# Altium schematic sheet BEMF_Detector.SchDoc
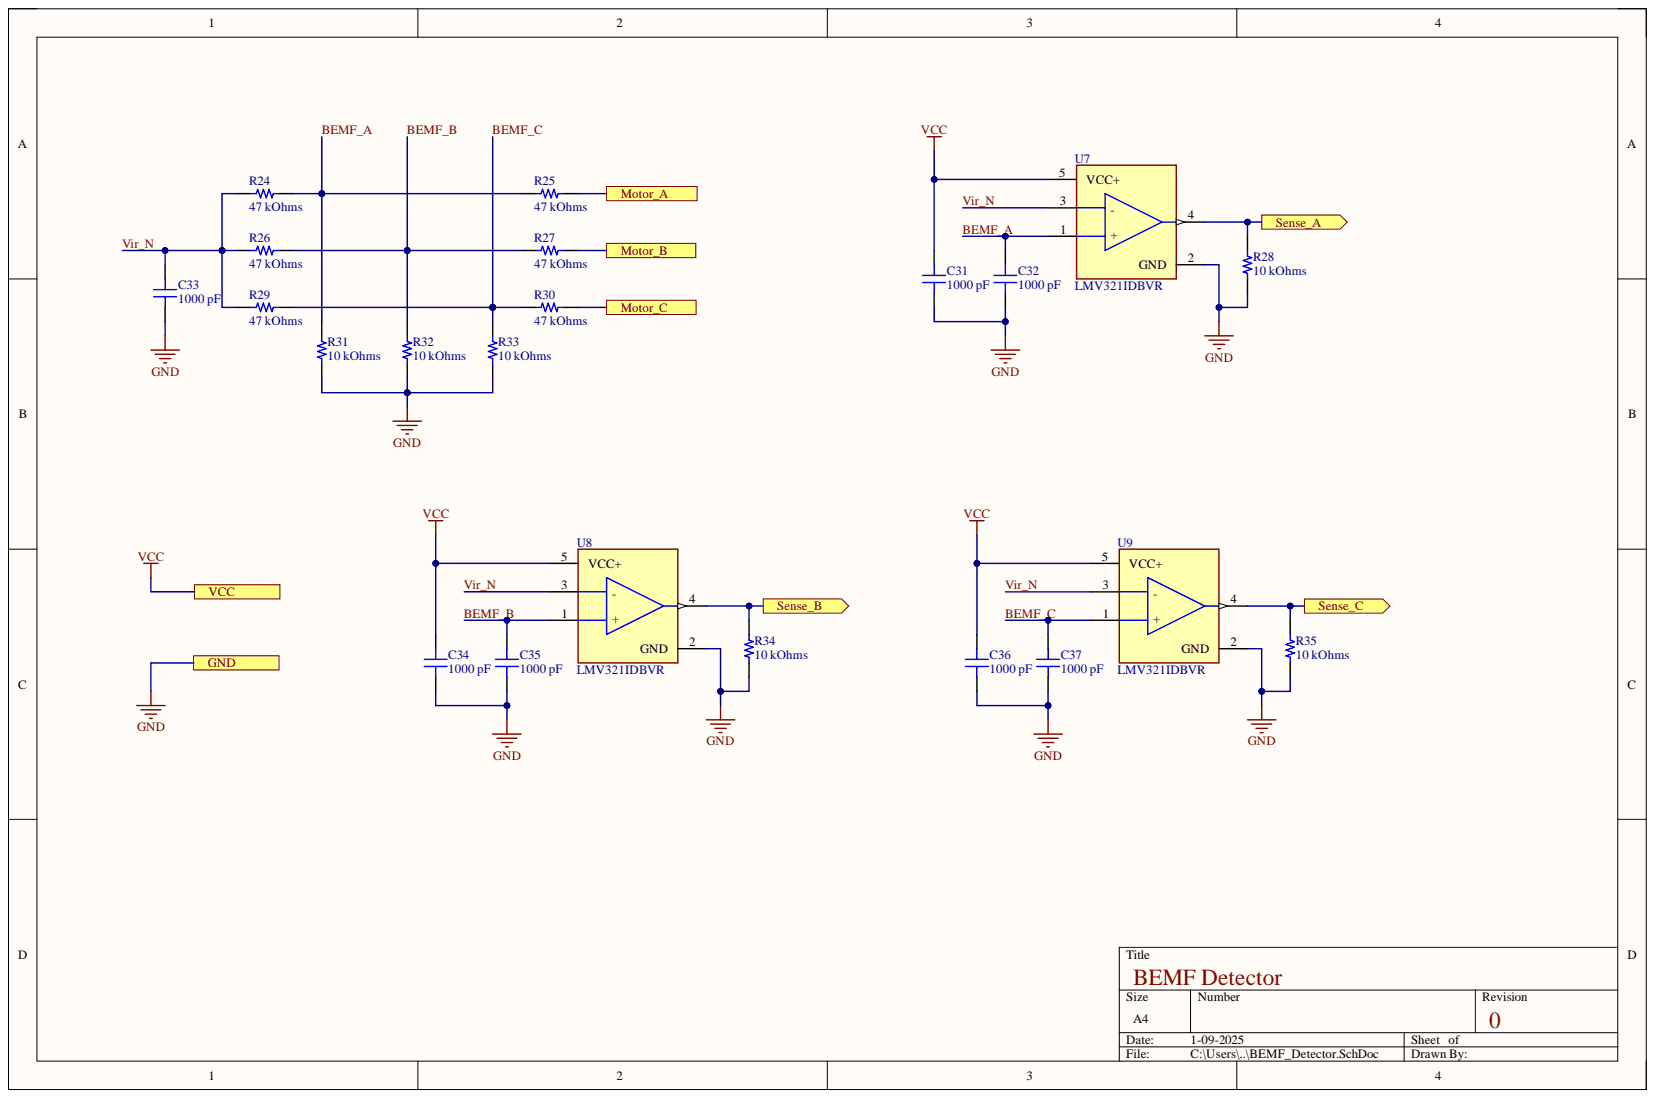
[diagram p0: BEMF_Detector.SchDoc]

## Parts
C31  1000 pF  [CAP 0201_0603]
C32  1000 pF  [CAP 0201_0603]
C33  1000 pF  [CAP 0201_0603]
C34  1000 pF  [CAP 0201_0603]
C35  1000 pF  [CAP 0201_0603]
C36  1000 pF  [CAP 0201_0603]
C37  1000 pF  [CAP 0201_0603]
R24  47 kOhms  [YAGEO RES 0201_0603]
R25  47 kOhms  [YAGEO RES 0201_0603]
R26  47 kOhms  [YAGEO RES 0201_0603]
R27  47 kOhms  [YAGEO RES 0201_0603]
R28  10 kOhms  [YAGEO RES 0201_0603]
R29  47 kOhms  [YAGEO RES 0201_0603]
R30  47 kOhms  [YAGEO RES 0201_0603]
R31  10 kOhms  [YAGEO RES 0201_0603]
R32  10 kOhms  [YAGEO RES 0201_0603]
R33  10 kOhms  [YAGEO RES 0201_0603]
R34  10 kOhms  [YAGEO RES 0201_0603]
R35  10 kOhms  [YAGEO RES 0201_0603]
U7  LMV321IDBVR  [FP-DBV0005A-IPC_B]
U8  LMV321IDBVR  [FP-DBV0005A-IPC_B]
U9  LMV321IDBVR  [FP-DBV0005A-IPC_B]

## Ports
GND, Motor_A, Motor_B, Motor_C, Sense_A, Sense_B, Sense_C, VCC

## Nets
BEMF_A: C32.2 R24.2 R25.1 R31.2 U7.1
BEMF_B: C35.2 R26.2 R27.1 R32.2 U8.1
BEMF_C: C37.2 R29.2 R30.1 R33.2 U9.1
GND: C31.1 C32.1 C33.1 C34.1 C35.1 C36.1 C37.1 R28.1 R31.1 R32.1 R33.1 R34.1 R35.1 U7.2(GND) U8.2(GND) U9.2(GND) ; port GND
Motor_A: R25.2 ; port Motor_A
Motor_B: R27.2 ; port Motor_B
Motor_C: R30.2 ; port Motor_C
Sense_A: R28.2 U7.4 ; port Sense_A
Sense_B: R34.2 U8.4 ; port Sense_B
Sense_C: R35.2 U9.4 ; port Sense_C
VCC: C31.2 C34.2 C36.2 U7.5(VCC+) U8.5(VCC+) U9.5(VCC+) ; port VCC
Vir_N: C33.2 R24.1 R26.1 R29.1 U7.3 U8.3 U9.3

## Library data of the parts
C31-C37 = 885012204006: CAP CER 1000PF 25V X7R 0201; manufacturer: Würth Elektronik; Database Table Name: Capacitors - Ceramic - 0201; Tolerance: ±10%; Applications: General Purpose; Capacitance: 1000 pF; Component Kind: Standard; componentlink1description: Datasheet; componentlink1url: https://www.we-online.com/katalog/datasheet/885012204006.pdf; componentlink2description: DigiKey Link; componentlink2url: https://www.digikey.com/en/products/detail/würth-elektronik/885012204006/17734037; Device Package: 0201 (0603 Metric); lastupdated: 04-06-2024 19:00:59; Library Name: Celestial Altium Library - altium_library.DbLib; Lifecycle Status: Active; Minimum Order: 1; Mounting Type: Surface Mount, MLCC; Operating Temperature: -55°C ~ 125°C; Package / Case: 0201 (0603 Metric); packaging: TapeAndReel; Part Number: 885012204006; Part Status: Active; partid: 47480; price: 0.01; series: WCAP-CSGP; Size / Dimension: 0.024" L x 0.012" W (0.60mm x 0.30mm); Supplier 1: DigiKey; Supplier Part Number 1: 732-885012204006CT-ND; Temperature Coefficient: X7R; Thickness (Max): 0.013" (0.33mm); Value: 1000 pF; Voltage - Rated: 25V
R24-R27 R29 R30 = RC0201FR-0747KL: RES 47K OHM 1% 1/20W 0201; Manufacturer: Yageo; Database Table Name: Resistors - Surface Mount - 0201; Power (Watts): 0.05W, 1/20W; Temperature Coefficient: ±200ppm/°C; Component Kind: Standard; ComponentLink1Description: Datasheet; ComponentLink1URL: https://www.yageo.com/upload/media/product/productsearch/datasheet/rchip/PYu-RC_Group_51_RoHS_L_10.pdf; ComponentLink2Description: DigiKey Link; ComponentLink2URL: https://www.digikey.com/en/products/detail/yageo/RC0201FR-0747KL/3202409; Composition: Thick Film; Device Package: 0201 (0603 Metric); Features: Moisture Resistant; Height - Seated (Max): 0.010" (0.26mm); LastUpdated: 25-07-2024 09:16:23; Library Name: Celestial Altium Library - altium_library.DbLib; Lifecycle Status: Active; Minimum Order: 1; Number of Terminations: 2; Operating Temperature: -55°C ~ 125°C; Package / Case: 0201 (0603 Metric); Packaging: TapeAndReel; Part Number: RC0201FR-0747KL; Part Status: Active; PartId: 2291; Price: 0.01; Resistance: 47 kOhms; Series: RC_L; Size / Dimension: 0.024" L x 0.012" W (0.60mm x 0.30mm); Supplier 1: DigiKey; Supplier Device Package: 0201; Supplier Part Number 1: 311-47KMCT-ND; Tolerance: ±1%; Value: 47 kOhms
R28 R31-R35 = RC0201FR-0710KL: RES 10K OHM 1% 1/20W 0201; Manufacturer: Yageo; Database Table Name: Resistors - Surface Mount - 0201; Power (Watts): 0.05W, 1/20W; Temperature Coefficient: ±200ppm/°C; Component Kind: Standard; ComponentLink1Description: Datasheet; ComponentLink1URL: https://www.yageo.com/upload/media/product/productsearch/datasheet/rchip/PYu-RC_Group_51_RoHS_L_10.pdf; ComponentLink2Description: DigiKey Link; ComponentLink2URL: https://www.digikey.com/en/products/detail/yageo/RC0201FR-0710KL/1948870; Composition: Thick Film; Device Package: 0201 (0603 Metric); Features: Moisture Resistant; Height - Seated (Max): 0.010" (0.26mm); LastUpdated: 25-07-2024 09:16:23; Library Name: Celestial Altium Library - altium_library.DbLib; Lifecycle Status: Active; Minimum Order: 1; Number of Terminations: 2; Operating Temperature: -55°C ~ 125°C; Package / Case: 0201 (0603 Metric); Packaging: TapeAndReel; Part Number: RC0201FR-0710KL; Part Status: Active; PartId: 2281; Price: 0.01; Resistance: 10 kOhms; Series: RC_L; Size / Dimension: 0.024" L x 0.012" W (0.60mm x 0.30mm); Supplier 1: DigiKey; Supplier Device Package: 0201; Supplier Part Number 1: 311-10.0KMCT-ND; Tolerance: ±1%; Value: 10 kOhms
U7-U9 = CMP-00038-1: Single, 5.5-V, 1-MHz, RRO operational amplifier 5-SOT-23 -40 to 125; Amplifier Type: GENERAL PURPOSE; Bandwidth: 1MHz; Common Mode Rejection Ratio: 50dB; Input Bias Current: 250nA; Input Offset Voltage (Vos): 7mV; Max Supply Voltage: 5.5V; Min Supply Voltage: 2.7V; Number of Amplifiers: 1; Operating Supply Current: 130uA; Output Current: 160mA; Slew Rate: 1V/µs; Supply Current: 250uA; Voltage Gain: 100dB; Case/Package: SOT-23-5; Component Kind: Standard; Gain Bandwidth Product: 1MHz; Lead Free: Lead Free; Library Name: CSD Robocon Workspace; Max Operating Temperature: 125°C; Min Operating Temperature: -40°C; Mounting Technology: Surface Mount; Number of Channels: 1; Number of Circuits: 1; Number of Elements: 1; Output Current per Channel: 160mA; Package Quantity: 1; Packaging: Tape and Reel; Pins: 5; Radiation Hardening: No; Reach SVHC Compliant: No; RoHS Compliant: Yes; Series: -; Technology: BICMOS; Unity Gain Bandwidth Product: 1MHz
